# Altium schematic sheet BLUE_PILL.SchDoc
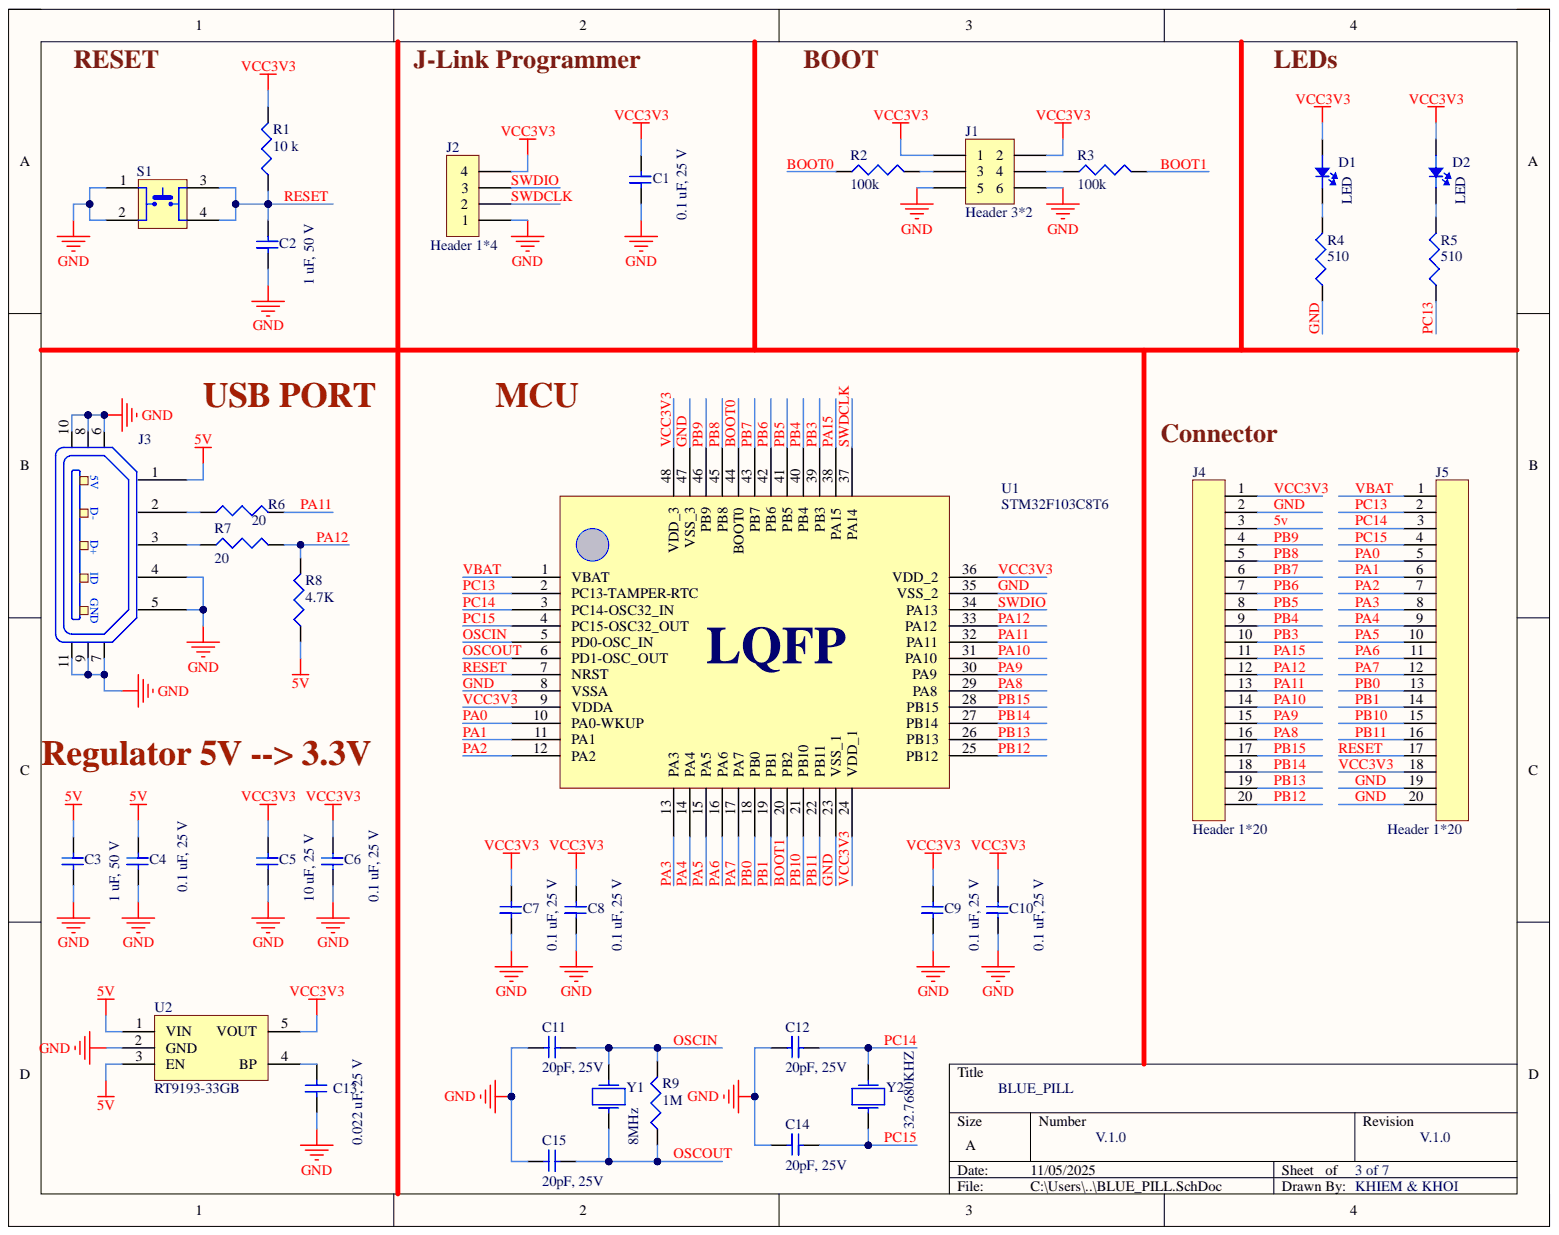
[diagram p0: BLUE_PILL.SchDoc]

## Parts
C1  0.1 uF, 25 V  [C0805]
C2  1 uF, 50 V  [C0805]
C3  1 uF, 50 V  [C0805]
C4  0.1 uF, 25 V  [C0805]
C5  10 uF, 25 V  [C0805]
C6  0.1 uF, 25 V  [C0805]
C7  0.1 uF, 25 V  [C0805]
C8  0.1 uF, 25 V  [C0805]
C9  0.1 uF, 25 V  [C0805]
C10  0.1 uF, 25 V  [C0805]
C11  20pF, 25V  [C0805]
C12  20pF, 25V  [C0805]
C13  0.022 uF, 25 V  [C0805]
C14  20pF, 25V  [C0805]
C15  20pF, 25V  [C0805]
D1  LED  [LED0603]
D2  LED  [LED0603]
J1  Header 3*2  [Header 3*2]
J2  Header 1*4  [Header 4*1]
J3  10118194-0001LF  [10118194-0001LF]  (value not drawn)
J4  Header 1*20  [header 20*1]
J5  Header 1*20  [header 20*1]
R1  10 k  [R0805]
R2  100k  [R0805]
R3  100k  [R0805]
R4  510  [R0805]
R5  510  [R0805]
R6  20  [R0805]
R7  20  [R0805]
R8  4.7K  [R0805]
R9  1M  [R0805]
S1  PTS526SM15SMTR2LFS  [PTS526SM15SMTR2LFS]  (value not drawn)
U1  STM32F103C8T6  Case/Package: LQFP  [LQFP48]
U2  RT9193-33GB  [SOT95P280X100-5N]
Y1  8MHz  [FC4SD]
Y2  32.7680KHZ  [CM8V-T1A]

## Nets
5v: C3.2 C4.2 J3.1 J4.3 R8.1 U2.1(VIN) U2.3(EN)
BOOT0: R2.1 U1.44(BOOT0)
BOOT1: R3.2 U1.20(PB2)
GND: C1.1 C2.1 C3.1 C4.1 C5.1 C6.1 C7.1 C8.1 C9.1 C10.1 C11.1 C12.1 C13.1 C14.1 C15.1 J1.5 J1.6 J2.1 J3.4 J3.5 J3.6 J3.7 J3.8 J3.9 J3.10 J3.11 J4.2 J5.19 J5.20 R4.1 S1.1 S1.2 U1.8(VSSA) U1.23(VSS_1) U1.35(VSS_2) U1.47(VSS_3) U2.2(GND)
OSCIN: C11.2 R9.2 U1.5(PD0-OSC_IN) Y1.2
OSCOUT: C15.2 R9.1 U1.6(PD1-OSC_OUT) Y1.1
PA0: J5.5 U1.10(PA0-WKUP)
PA1: J5.6 U1.11(PA1)
PA2: J5.7 U1.12(PA2)
PA3: J5.8 U1.13(PA3)
PA4: J5.9 U1.14(PA4)
PA5: J5.10 U1.15(PA5)
PA6: J5.11 U1.16(PA6)
PA7: J5.12 U1.17(PA7)
PA8: J4.16 U1.29(PA8)
PA9: J4.15 U1.30(PA9)
PA10: J4.14 U1.31(PA10)
PA11: J4.13 R6.2 U1.32(PA11)
PA12: J4.12 R7.2 R8.2 U1.33(PA12)
PA15: J4.11 U1.38(PA15)
PB0: J5.13 U1.18(PB0)
PB1: J5.14 U1.19(PB1)
PB3: J4.10 U1.39(PB3)
PB4: J4.9 U1.40(PB4)
PB5: J4.8 U1.41(PB5)
PB6: J4.7 U1.42(PB6)
PB7: J4.6 U1.43(PB7)
PB8: J4.5 U1.45(PB8)
PB9: J4.4 U1.46(PB9)
PB10: J5.15 U1.21(PB10)
PB11: J5.16 U1.22(PB11)
PB12: J4.20 U1.25(PB12)
PB13: J4.19 U1.26(PB13)
PB14: J4.18 U1.27(PB14)
PB15: J4.17 U1.28(PB15)
PC13: J5.2 R5.1 U1.2(PC13-TAMPER-RTC)
PC14: C12.2 J5.3 U1.3(PC14-OSC32_IN) Y2.2
PC15: C14.2 J5.4 U1.4(PC15-OSC32_OUT) Y2.1
RESET: C2.2 J5.17 R1.1 S1.3 S1.4 U1.7(NRST)
SWDCLK: J2.2 U1.37(PA14)
SWDIO: J2.3 U1.34(PA13)
VBAT: J5.1 U1.1(VBAT)
VCC3V3: C1.2 C5.2 C6.2 C7.2 C8.2 C9.2 C10.2 D1.1 D2.1 J1.1 J1.2 J2.4 J4.1 J5.18 R1.2 U1.9(VDDA) U1.24(VDD_1) U1.36(VDD_2) U1.48(VDD_3) U2.5(VOUT)
NetC13_2: C13.2 U2.4(BP)
NetD1_2: D1.2 R4.2
NetD2_2: D2.2 R5.2
NetJ1_3: J1.3 R2.2
NetJ1_4: J1.4 R3.1
NetJ3_2: J3.2 R6.1
NetJ3_3: J3.3 R7.1

## Library data of the parts
C1 C4 C6-C10 = C0805C104K3RACTU: Multilayer Ceramic Capacitor, 0.1 uF, 25 V, ± 10%, X7R, 0805 [2012 Metric]; Manufacturer: KEMET; Material: 4241ConductorMaterial; Temperature Coefficient: 30ppm/°C; Value: 100nF; Voltage Rating: 25V; Case Code (Imperial): 0805; Case Code (Metric): 2012; Case/Package: 0805; Category: Ceramic Capacitors; Component Kind: Standard; ComponentLink1Description: https://datasheet.ciiva.com/7842/kem-c1002-x7r-smd-7842538.pdf?src-supplier=Element14; ComponentLink1URL: https://datasheet.ciiva.com/7842/kem-c1002-x7r-smd-7842538.pdf?src-supplier=Element14; ComponentLink2Description: https://datasheet.ciiva.com/7842/kem-c1002-x7r-smd-7842536.pdf?src-supplier=Element14; ComponentLink2URL: https://datasheet.ciiva.com/7842/kem-c1002-x7r-smd-7842536.pdf?src-supplier=Element14; ComponentLink3Description: https://datasheet.ciiva.com/18193/getdatasheetpartid-385649-18193413.pdf?src-supplier=Verical; ComponentLink3URL: https://datasheet.ciiva.com/18193/getdatasheetpartid-385649-18193413.pdf?src-supplier=Verical; Construction: Flat; Depth: 1.25mm; Dielectric: X7R; Height: 0.9mm; Lead Free: 5697LeadFree; Lead Pitch: 0.75mm; Length: 2mm; Library Name: Blue_Pill_LIB.IntLib; Manufacturer Part Number: C0805C104K3RACTU; Max Operating Temperature: 125°C; Min Operating Temperature: -55°C; Mounting Technology: Surface Mount,PCB; Package Quantity: 4000; Packaging: Tape and Reel; Pins: 2NUMBER; Pricing: 1=0.11, 10=0.048, 50=0.048, 100=0.028, 1000=0.024, 10000=0.016 (USD); Radiation Hardening: No; Reach SVHC Compliant: 4186SVHC; RoHS: Yes; RoHS Compliant: Yes; Series: SMD Comm X7R; Stock: 364608; Supplier: Mouser; Supplier Part Number: 80-C0805C104K3R; Termination: SMD/SMT; Thickness: 889um; Tolerance: 10%; Voltage Rating (DC): 25V; Width: 1.2mm
C2 C3 = C0805C105K5RACTU: Multilayer Ceramic Capacitor, 1 uF, 50 V, ± 10%, X7R, 0805 [2012 Metric]; Manufacturer: KEMET; Material: 4241ConductorMaterial; Value: 1uF; Voltage: 50V; Case Code (Imperial): 0805; Case Code (Metric): 2012; Case/Package: 0805; Category: Ceramic Capacitors; Component Kind: Standard; ComponentLink1Description: https://datasheet.ciiva.com/7842/1732744-7842192.pdf?src-supplier=Element14; ComponentLink1URL: https://datasheet.ciiva.com/7842/1732744-7842192.pdf?src-supplier=Element14; ComponentLink2Description: https://datasheet.ciiva.com/22700/1851736-22700523.pdf?src-supplier=Element14; ComponentLink2URL: https://datasheet.ciiva.com/22700/1851736-22700523.pdf?src-supplier=Element14; Construction: Flat; Depth: 1.25mm; Dielectric: X7R; Dielectric Material: Ceramic; Height: 1.25mm; Length: 2mm; Library Name: Blue_Pill_LIB.IntLib; Manufacturer Part Number: C0805C105K5RACTU; Max Operating Temperature: 125°C; Min Operating Temperature: -55°C; Mounting Technology: Surface Mount,PCB; Package Quantity: 1; Packaging: Tape and Reel; Pricing: 1=0.34, 10=0.17, 50=0.17, 100=0.11, 1000=0.087, 10000=0.077 (USD); Reach SVHC Compliant: No; RoHS: Yes; RoHS Compliant: Yes; Series: C; Stock: 466053; Supplier: Mouser; Supplier Part Number: 80-C0805C105K5R; Termination: SMD/SMT; Thickness: 0.055inch; Tolerance: 10%; Voltage Rating: 50V; Voltage Rating (DC): 50V; Width: 0.049inch
C5 = CC0805KKX5R8BB106: Multilayer Ceramic Capacitor, 10 uF, 25 V, ± 10%, X5R, 0805 [2012 Metric]; Manufacturer: Yageo; Material: 4241ConductorMaterial; Value: 10uF; Voltage Rating: 25V; Case Code (Imperial): 0805; Case Code (Metric): 2012; Case/Package: 0805; Category: Ceramic Capacitors; Component Kind: Standard; ComponentLink1Description: http://www.mouser.com/ds/2/447/UPY-GPHC_X5R_4V-to-50V_25-1131599.pdf?src-supplier=Mouser; ComponentLink1URL: http://www.mouser.com/ds/2/447/UPY-GPHC_X5R_4V-to-50V_25-1131599.pdf?src-supplier=Mouser; ComponentLink2Description: https://datasheet.octopart.com/CC0805KKX5R8BB106-Yageo-datasheet-140260304.pdf?src-supplier=Newark; ComponentLink2URL: https://datasheet.octopart.com/CC0805KKX5R8BB106-Yageo-datasheet-140260304.pdf?src-supplier=Newark; ComponentLink3Description: https://datasheet.octopart.com/CC0805KKX5R8BB106-Yageo-datasheet-170350636.pdf?src-supplier=Future+Electronics; ComponentLink3URL: https://datasheet.octopart.com/CC0805KKX5R8BB106-Yageo-datasheet-170350636.pdf?src-supplier=Future+Electronics; ComponentLink4Description: http://www.yageo.com/documents/recent/UPY-GPHC_X5R_4V-to-50V_25.pdf?src-supplier=Digikey; ComponentLink4URL: http://www.yageo.com/documents/recent/UPY-GPHC_X5R_4V-to-50V_25.pdf?src-supplier=Digikey; Dielectric: X5R; Halogen Free: 5694HalogenFree; Height: 1.45mm; Lead Free: 5697LeadFree; Length: 0.079inch; Library Name: Blue_Pill_LIB.IntLib; Manufacturer Part Number: CC0805KKX5R8BB106; Max Operating Temperature: 85°C; Min Operating Temperature: -55°C; Mounting Technology: Surface Mount; Packaging: Tape and Reel; Pricing: 20=0.169, 100=0.135, 500=0.11, 800=0.154, 1000=0.093 (GBP); RoHS: Yes; RoHS Compliant: Yes; Series: CC; Stock: 60; Supplier: Rs; Supplier Part Number: 1988078; Termination: SMD/SMT; Thickness: 0.057inch; Tolerance: 10%; Voltage Rating (DC): 25V; Weight: 0.000194oz; Width: 0.049inch
C11 C12 C14 C15 = C0805C200J3HACAUTO: Cap Cer 0805 20PF 25V Ultra Stab; Manufacturer: KEMET; Category: Ceramic Capacitors; Component Kind: Standard; Library Name: Blue_Pill_LIB.IntLib; Manufacturer Part Number: C0805C200J3HACAUTO; Pricing: 1=0.15, 10=0.061, 50=0.061, 100=0.036, 1000=0.028, 10000=0.02 (USD); RoHS: Yes; RoHS Compliant: Yes; Stock: 11117; Supplier: Mouser; Supplier Part Number: 80-C0805C200J3HAUTO
C13 = C0805C223J3GACTU: Multilayer Ceramic Capacitor, 0.022 uF, 25 V, ± 5%, C0G (NP0), 0805 [2012 Metric]; Manufacturer: KEMET; Dielectric Material: 4241ConductorMaterial; Dissipation Factor: 0.1%; Temperature Coefficient: 30ppm/°C; Value: 22nF; Voltage: 25V; Case Code (Imperial): 0805; Case Code (Metric): 2012; Case/Package: 0805; Category: Ceramic Capacitors; Component Kind: Standard; ComponentLink1Description: http://components.arrow.com/part/dataview/46124259S8614547N7429?src-supplier=Arrow+Electronics; ComponentLink1URL: http://components.arrow.com/part/dataview/46124259S8614547N7429?src-supplier=Arrow+Electronics; ComponentLink2Description: http://www.farnell.com/datasheets/2741014.pdf?src-supplier=Farnell; ComponentLink2URL: http://www.farnell.com/datasheets/2741014.pdf?src-supplier=Farnell; ComponentLink3Description: http://www.mouser.com/ds/2/212/KEM_C1003_C0G_SMD-1101588.pdf?src-supplier=Mouser; ComponentLink3URL: http://www.mouser.com/ds/2/212/KEM_C1003_C0G_SMD-1101588.pdf?src-supplier=Mouser; ComponentLink4Description: https://datasheet.octopart.com/C0805C223J3GACTU-Kemet-datasheet-14356739.pdf?src-supplier=Newark; ComponentLink4URL: https://datasheet.octopart.com/C0805C223J3GACTU-Kemet-datasheet-14356739.pdf?src-supplier=Newark; ComponentLink5Description: https://api.kemet.com/component-edge/download/datasheet/C0805C223J3GACTU.pdf?src-supplier=Digi-Key; ComponentLink5URL: https://api.kemet.com/component-edge/download/datasheet/C0805C223J3GACTU.pdf?src-supplier=Digi-Key; ComponentLink6Description: http://www.kemet.com/docfinder?Partnumber=C0805C223J3GACTU&src-supplier=Digikey; ComponentLink6URL: http://www.kemet.com/docfinder?Partnumber=C0805C223J3GACTU&src-supplier=Digikey; ComponentLink7Description: https://www.verical.com/server-webapp/html/getDatasheet?partID=512137&src-supplier=Verical; ComponentLink7URL: https://www.verical.com/server-webapp/html/getDatasheet?partID=512137&src-supplier=Verical; ComponentLink8Description: http://www.farnell.com/datasheets/2741014.pdf?src-supplier=Newark; ComponentLink8URL: http://www.farnell.com/datasheets/2741014.pdf?src-supplier=Newark; ComponentLink9Description: https://datasheet.octopart.com/C0805C223J3GACTU-Kemet-datasheet-66532318.pdf?src-supplier=Element14; ComponentLink9URL: https://datasheet.octopart.com/C0805C223J3GACTU-Kemet-datasheet-66532318.pdf?src-supplier=Element14; ComponentLink10Description: https://datasheet.octopart.com/C0805C223J3GACTU-KEMET-datasheet-101576230.pdf?src-supplier=Farnell; ComponentLink10URL: https://datasheet.octopart.com/C0805C223J3GACTU-KEMET-datasheet-101576230.pdf?src-supplier=Farnell; ComponentLink11Description: http://www.farnell.com/datasheets/2741014.pdf?src-supplier=Element14; ComponentLink11URL: http://www.farnell.com/datasheets/2741014.pdf?src-supplier=Element14; ComponentLink12Description: http://capacitoredge.kemet.com/capedge2/DataSheet?pn=C0805C223J3GACTU&src-supplier=Avnet; ComponentLink12URL: http://capacitoredge.kemet.com/capedge2/DataSheet?pn=C0805C223J3GACTU&src-supplier=Avnet; ComponentLink13Description: https://datasheet.octopart.com/C0805C223J3GACTU-KEMET-datasheet-37178240.pdf?src-supplier=Electro+Sonic; ComponentLink13URL: https://datasheet.octopart.com/C0805C223J3GACTU-KEMET-datasheet-37178240.pdf?src-supplier=Electro+Sonic; Construction: Flat; Depth: 1.25mm; Dielectric: C0G; Height: 0.9mm; Lead Free: 5697LeadFree; Length: 2mm; Library Name: Blue_Pill_LIB.IntLib; Manufacturer Part Number: C0805C223J3GACTU; Material: 4241ConductorMaterial; Max Operating Temperature: 125°C; Min Operating Temperature: -55°C; Mounting Technology: Surface Mount; Package Quantity: 4000; Packaging: Tape and Reel; Pricing: 1=0.41, 10=0.27, 50=0.27, 100=0.163, 1000=0.109, 10000=0.088 (USD); Radiation Hardening: No; Reach SVHC Compliant: No; RoHS: Yes; RoHS Compliant: Yes; Series: SMD Comm C0G; Stock: 19291; Supplier: Mouser; Supplier Part Number: 80-C0805C223J3G; Termination: SMD/SMT; Thickness: 0.039inch; Tolerance: 5%; Voltage Rating: 25V; Voltage Rating (DC): 25V; Width: 1.2mm
D1 D2 = LTST-C191KFKT: Orange 0603 130° Water Clear 90 mcd 2 V SMT Rectangular Flat Top LED; Manufacturer: Vishay Lite-On; Dominant Wavelength: 605nm; Forward Current: 30mA; Forward Voltage: 2V; Lens Color: Colorless; Lens Size: 0.8mm; Lens Style: Flat,Diffused,Colorless,Rectangular; Luminous Intensity: 90mcd; Number of Elements: 1; Power Dissipation: 75mW; Reverse Voltage: 5V; Test Current: 20mA; Wavelength: 611nm; Case Code (Imperial): 0603; Case Code (Metric): 1608; Case/Package: 0603; Category: LEDs; Color: Orange; Component Kind: Standard; ComponentLink1Description: https://datasheet.ciiva.com/2109/ltst-c191kfkt-2109968.pdf?src-supplier=Digikey; ComponentLink1URL: https://datasheet.ciiva.com/2109/ltst-c191kfkt-2109968.pdf?src-supplier=Digikey; ComponentLink2Description: https://datasheet.ciiva.com/11775/0900766b80dc02b0-11775613.pdf?src-supplier=Rs; ComponentLink2URL: https://datasheet.ciiva.com/11775/0900766b80dc02b0-11775613.pdf?src-supplier=Rs; ComponentLink3Description: https://datasheet.ciiva.com/12400/100-ltst-c191kfkt-39904-12400811.pdf?src-supplier=Mouser; ComponentLink3URL: https://datasheet.ciiva.com/12400/100-ltst-c191kfkt-39904-12400811.pdf?src-supplier=Mouser; ComponentLink4Description: https://datasheet.ciiva.com/15201/getdatasheetpartid-631075-15201391.pdf?src-supplier=Verical; ComponentLink4URL: https://datasheet.ciiva.com/15201/getdatasheetpartid-631075-15201391.pdf?src-supplier=Verical; ComponentLink5Description: https://datasheet.ciiva.com/2109/s-110-ltst-c191kfkt-2109969.pdf?src-supplier=Digikey; ComponentLink5URL: https://datasheet.ciiva.com/2109/s-110-ltst-c191kfkt-2109969.pdf?src-supplier=Digikey; Depth: 0.8mm; Height: 0.65mm; Illumination Color: Orange; Lead Free: 5697LeadFree; Length: 1.6mm; Lens Transparency: Clear; Library Name: Blue_Pill_LIB.IntLib; Manufacturer Part Number: LTST-C191KFKT; Max Operating Temperature: 85°C; Min Operating Temperature: -55°C; Mounting Technology: Surface Mount; Number of LEDs: 1; Package Quantity: 5000; Packaging: Tape and Reel; Pins: 2; Power Rating: 75mW; Pricing: 40000=0.028, 125000=0.0261 (USD); Radiation Hardening: No; RoHS: Yes; RoHS Compliant: Yes; Series: -; Stock: 40000; Supplier: Arrow Electronics; Supplier Part Number: LTST-C191KFKT; Viewing Angle: 130°; Width: 0.8mm
J1 = M20-9980345: Conn Unshrouded Header HDR 6 POS 2.54mm Solder ST Top Entry Thru-Hole Bulk/Loose; Manufacturer: Harwin; Category: Headers and Wire Housings; Component Kind: Standard; ComponentLink1Description: https://datasheet.ciiva.com/6789/91635-6789923.pdf?src-supplier=Newark; ComponentLink1URL: https://datasheet.ciiva.com/6789/91635-6789923.pdf?src-supplier=Newark; ComponentLink2Description: https://datasheet.ciiva.com/11746/0900766b80da602b-11746662.pdf?src-supplier=Rs; ComponentLink2URL: https://datasheet.ciiva.com/11746/0900766b80da602b-11746662.pdf?src-supplier=Rs; ComponentLink3Description: https://datasheet.ciiva.com/26852/getdatasheetpartid-420465-26852189.pdf?src-supplier=Verical; ComponentLink3URL: https://datasheet.ciiva.com/26852/getdatasheetpartid-420465-26852189.pdf?src-supplier=Verical; ComponentLink4Description: https://datasheet.ciiva.com/8046/112195-8046904.pdf?src-supplier=Element14; ComponentLink4URL: https://datasheet.ciiva.com/8046/112195-8046904.pdf?src-supplier=Element14; ComponentLink5Description: https://datasheet.ciiva.com/6830/m20-998-6830775.pdf?src-supplier=Digikey; ComponentLink5URL: https://datasheet.ciiva.com/6830/m20-998-6830775.pdf?src-supplier=Digikey; Library Name: Blue_Pill_LIB.IntLib; Manufacturer Part Number: M20-9980345; Pricing: 250=0.146, 1000=0.124, 5000=0.1, 10000=0.092 (GBP); RoHS: Yes; Stock: 1710; Supplier: Rs; Supplier Part Number: 6812947P
J2 = M20-9960445: Conn Unshrouded Header HDR 4 POS 2.54mm Solder RA Thru-Hole Bag; Manufacturer: Harwin; Current Rating: 3A; Gender: Male; Number of Contacts: 4; Voltage Rating: 750V; Case/Package: SIL; Category: Headers and Wire Housings; Color: Black; Component Kind: Standard; ComponentLink1Description: https://datasheet.ciiva.com/9480/m20-996-9480181.pdf?src-supplier=Avnet; ComponentLink1URL: https://datasheet.ciiva.com/9480/m20-996-9480181.pdf?src-supplier=Avnet; ComponentLink2Description: https://datasheet.ciiva.com/12921/m20-996-12921995.pdf?src-supplier=Mouser; ComponentLink2URL: https://datasheet.ciiva.com/12921/m20-996-12921995.pdf?src-supplier=Mouser; Contact Finish - Mating: GOLD; Contact Material: Brass; Contact Mating Length: 0.24inch; Contact Plating: Gold; Contact Shape: Square; Contact Type: Male Pin; Fastening Type: Pull; Flammability Rating: UL94 V-0; Housing Material: Nylon; Insulation Color: Black; Insulation Material: Nylon; Library Name: Blue_Pill_LIB.IntLib; Manufacturer Part Number: M20-9960445; Max Operating Temperature: 105°C; Min Operating Temperature: -40°C; Mounting Technology: Through Hole; Number of Positions: 4; Number of Rows: 1; Package Quantity: 1000; Packaging: Bulk; Pitch: 2.54mm; Pricing: 1=0.31, 10=0.267, 100=0.2079, 1000=0.14847, 5000=0.12726, 10000=0.11878 (USD); RoHS: Yes; RoHS Compliant: Yes; Series: M20; Stock: 9573; Supplier: Digikey; Supplier Part Number: 952-3286-ND; Termination: Solder
J3 = 10118194-0001LF: CONN USB MICRO B RECPT SMT R/A; Manufacturer: Amphenol ICC / FCI; Connector Type: USB,Receptacle; Current Rating: 1.8A; Gender: Receptacle; Insulation Resistance: 1GR; Max Voltage Rating (AC): 100V; Number of Contacts: 5; Number of Ports: 1; Category: USB Connectors; Color: Black; Component Kind: Standard; Contact Material: Copper Alloy; Contact Plating: Gold; Depth: 5mm; Features: Shielded; Flammability Rating: UL94 V-0; Housing Material: Polymer; Length: 8.05mm; Library Name: Blue_Pill_LIB.IntLib; Manufacturer Part Number: 10118194-0001LF; Max Operating Temperature: 85°C; Min Operating Temperature: -55°C; Mounting Technology: Surface Mount,Horizontal; Orientation: Right Angle; Package Quantity: 9600; Packaging: Tape and Reel; Pins: 5; Pricing: 9600=0.1965 (USD); RoHS: No; Series: -; Stock: 19200; Supplier: Arrow Electronics; Supplier Part Number: 10118194-0001LF; Termination: Solder
J4 J5 = M20-9992045: 2.54mm (0.1") Pitch SIL Vertical PC Tail Pin Header, 6.1mm mating pin height, gold (hi-temp mould), 20 contacts; Manufacturer: Harwin; Gender: Male; Number of Contacts: 20; Case/Package: SIL; Category: Headers and Wire Housings; Color: Black; Component Kind: Standard; ComponentLink1Description: https://datasheet.ciiva.com/5159/m20-999-351179-5159781.pdf?src-supplier=Mouser; ComponentLink1URL: https://datasheet.ciiva.com/5159/m20-999-351179-5159781.pdf?src-supplier=Mouser; Contact Mating Length: 0.24inch; Contact Plating: Gold; Contact Type: Male Pin; Library Name: Blue_Pill_LIB.IntLib; Manufacturer Part Number: M20-9992045; Mounting Technology: Through Hole; Number of Positions: 20; Number of Rows: 1; Package Quantity: 200; Packaging: Bulk; Pitch: 2.54mm; Pricing: 10=0.766, 50=0.699, 100=0.632, 250=0.575, 500=0.517, 1500=0.46 (EUR); Radiation Hardening: No; RoHS: Yes; RoHS Compliant: Yes; Series: M20; Stock: 1665; Supplier: Farnell; Supplier Part Number: 3226019; Termination: Solder
R1 = RC0805JR-0710KL: SMD Chip Resistor, 10 kOhm, ± 5%, 125 mW, 0805 [2012 Metric], Thick Film, General Purpose; Manufacturer: Yageo; Max Power Dissipation: 125mW; Temperature Coefficient: 100ppm/°C; Value: 10kR; Voltage Rating: 150V; Case Code (Imperial): 0805; Case Code (Metric): 2012; Case/Package: 0805; Category: Chip SMD Resistors; Component Kind: Standard; ComponentLink1Description: https://datasheet.ciiva.com/7983/444164-7983765.pdf?src-supplier=Element14; ComponentLink1URL: https://datasheet.ciiva.com/7983/444164-7983765.pdf?src-supplier=Element14; ComponentLink2Description: https://datasheet.ciiva.com/7941/444164-7941870.pdf?src-supplier=Element14; ComponentLink2URL: https://datasheet.ciiva.com/7941/444164-7941870.pdf?src-supplier=Element14; ComponentLink3Description: https://datasheet.ciiva.com/7941/444164-7941871.pdf?src-supplier=Element14; ComponentLink3URL: https://datasheet.ciiva.com/7941/444164-7941871.pdf?src-supplier=Element14; Construction: Rectangular; Contact Plating: Tin,Matte; Depth: 1.25mm; Features: Moisture Resistant; Height: 0.5mm; Lead Free: 5697LeadFree; Length: 2mm; Library Name: Blue_Pill_LIB.IntLib; Manufacturer Part Number: RC0805JR-0710KL; Max Operating Temperature: 155°C; Military Standard: Not; Min Operating Temperature: -55°C; Number of Terminations: 2; Packaging: Tape and Reel; Power Rating: 125mW; Pricing: 5000=0.0023, 25000=0.0021, 100000=0.002, 250000=0.002, 625000=0.0018 (USD); Radiation Hardening: No; Reach SVHC Compliant: Unknown; Resistance Tolerance: 5%; Resistor Type: Thick Film; RoHS: Yes; RoHS Compliant: Yes; Series: RC; Stock: 9465000; Supplier: Future Electronics; Supplier Part Number: 9330259; Termination: SMD/SMT; Tolerance: 5%; Width: 1.25mm
R2 R3 = RC0805FR-07100KL: SMD Chip Resistor, 100 kOhm, ± 1%, 125 mW, 0805 [2012 Metric], Thick Film, General Purpose; Manufacturer: Yageo; Max Power Dissipation: 125mW; Temperature Coefficient: 100ppm/°C; Value: 100kR; Voltage Rating: 150V; Case Code (Imperial): 0805; Case Code (Metric): 2012; Case/Package: 0805; Category: Chip SMD Resistors; Component Kind: Standard; ComponentLink1Description: https://datasheet.ciiva.com/11808/0900766b8106beab-11808858.pdf?src-supplier=Rs; ComponentLink1URL: https://datasheet.ciiva.com/11808/0900766b8106beab-11808858.pdf?src-supplier=Rs; ComponentLink2Description: https://datasheet.ciiva.com/7941/1686128-7941677.pdf?src-supplier=Element14; ComponentLink2URL: https://datasheet.ciiva.com/7941/1686128-7941677.pdf?src-supplier=Element14; ComponentLink3Description: https://datasheet.ciiva.com/7660/38627-7660796.pdf?src-supplier=Element14; ComponentLink3URL: https://datasheet.ciiva.com/7660/38627-7660796.pdf?src-supplier=Element14; ComponentLink4Description: https://datasheet.ciiva.com/22747/444164-22747534.pdf?src-supplier=Element14; ComponentLink4URL: https://datasheet.ciiva.com/22747/444164-22747534.pdf?src-supplier=Element14; ComponentLink5Description: https://datasheet.ciiva.com/2457/pyu-r-int-thick-7-2457018.pdf?src-supplier=Digikey; ComponentLink5URL: https://datasheet.ciiva.com/2457/pyu-r-int-thick-7-2457018.pdf?src-supplier=Digikey; ComponentLink6Description: https://datasheet.ciiva.com/2457/pyu-r-int-thick-7-2457019.pdf?src-supplier=Digikey; ComponentLink6URL: https://datasheet.ciiva.com/2457/pyu-r-int-thick-7-2457019.pdf?src-supplier=Digikey; ComponentLink7Description: https://datasheet.ciiva.com/2457/pyu-r-int-thick-7-2457017.pdf?src-supplier=Digikey; ComponentLink7URL: https://datasheet.ciiva.com/2457/pyu-r-int-thick-7-2457017.pdf?src-supplier=Digikey; ComponentLink8Description: https://datasheet.ciiva.com/12667/rc0805-12667001.pdf?src-supplier=Mouser; ComponentLink8URL: https://datasheet.ciiva.com/12667/rc0805-12667001.pdf?src-supplier=Mouser; ComponentLink9Description: https://datasheet.ciiva.com/7941/1697719-7941676.pdf?src-supplier=Element14; ComponentLink9URL: https://datasheet.ciiva.com/7941/1697719-7941676.pdf?src-supplier=Element14; ComponentLink10Description: https://datasheet.ciiva.com/7941/1697719-7941678.pdf?src-supplier=Element14; ComponentLink10URL: https://datasheet.ciiva.com/7941/1697719-7941678.pdf?src-supplier=Element14; ComponentLink11Description: https://datasheet.ciiva.com/16747/rc0805-16747226.pdf?src-supplier=Future+Electronics; ComponentLink11URL: https://datasheet.ciiva.com/16747/rc0805-16747226.pdf?src-supplier=Future+Electronics; Construction: Rectangular; Depth: 1.25mm; Features: Moisture Resistant; Height: 0.024inch; Lead Free: 5697LeadFree; Lead Pitch: 0.4mm; Length: 0.079inch; Library Name: Blue_Pill_LIB.IntLib; Manufacturer Part Number: RC0805FR-07100KL; Max Operating Temperature: 155°C; Military Standard: Not; Min Operating Temperature: -55°C; Number of Terminations: 2; Package Quantity: 1; Packaging: Tape and Reel; Pins: 2; Power Rating: 125mW; Pricing: 5000=0.0027, 25000=0.0025, 100000=0.0024, 250000=0.0023, 625000=0.0021 (USD); Reach SVHC Compliant: Unknown; Resistance Tolerance: 1%; Resistor Type: Thick Film; RoHS: Yes; RoHS Compliant: Yes; Series: RC; Stock: 2010000; Supplier: Future Electronics; Supplier Part Number: 2952521; Technology: THICK FILM; Termination: SMD/SMT; Tolerance: 1%; Voltage Rating (AC): 150V; Voltage Rating (DC): 150V; Width: 0.049inch
R4 R5 = RC0805JR-07510RL: Res Thick Film 0805 510 Ohm 5% 1/8W ±100ppm/°C SMD Paper T/R; Manufacturer: Yageo; Max Power Dissipation: 125mW; Value: 510R; Voltage Rating: 150V; Voltage Rating (DC): 300V; Case Code (Imperial): 0805; Case Code (Metric): 2012; Case/Package: 0805; Category: Chip SMD Resistors; Component Kind: Standard; ComponentLink1Description: https://datasheet.ciiva.com/2519/pyu-r-int-thick-7-2519247.pdf?src-supplier=Digikey; ComponentLink1URL: https://datasheet.ciiva.com/2519/pyu-r-int-thick-7-2519247.pdf?src-supplier=Digikey; ComponentLink2Description: https://datasheet.ciiva.com/2519/pyu-r-int-thick-7-2519248.pdf?src-supplier=Digikey; ComponentLink2URL: https://datasheet.ciiva.com/2519/pyu-r-int-thick-7-2519248.pdf?src-supplier=Digikey; ComponentLink3Description: https://datasheet.ciiva.com/2519/pyu-r-int-thick-7-2519246.pdf?src-supplier=Digikey; ComponentLink3URL: https://datasheet.ciiva.com/2519/pyu-r-int-thick-7-2519246.pdf?src-supplier=Digikey; ComponentLink4Description: https://datasheet.ciiva.com/12656/rc0805-12656876.pdf?src-supplier=Mouser; ComponentLink4URL: https://datasheet.ciiva.com/12656/rc0805-12656876.pdf?src-supplier=Mouser; ComponentLink5Description: https://datasheet.ciiva.com/16741/rc0805-16741256.pdf?src-supplier=Future+Electronics; ComponentLink5URL: https://datasheet.ciiva.com/16741/rc0805-16741256.pdf?src-supplier=Future+Electronics; Construction: Rectangular; Contact Plating: Tin,Lead; Depth: 1.25mm; Features: Moisture Resistant; Lead Free: Lead Free; Length: 2mm; Library Name: Blue_Pill_LIB.IntLib; Manufacturer Part Number: RC0805JR-07510RL; Max Operating Temperature: 155°C; Military Standard: Not; Min Operating Temperature: -55°C; Number of Terminations: 2; Packaging: Tape and Reel; Power Rating: 125mW; Pricing: 5000=0.0023, 25000=0.0021, 50000=0.0022, 100000=0.002, 200000=0.0021, 250000=0.002, 625000=0.002, 2000000=0.0018 (USD); RoHS: Yes; RoHS Compliant: Yes; Series: RC; Stock: 200000; Supplier: Future Electronics; Supplier Part Number: 3650397; Technology: THICK FILM; Temperature Coefficient: 100ppm/°C; Termination: SMD/SMT; Tolerance: 5%; Working Voltage: 150V
R6 R7 = RC0805JR-0720RL: SMD Chip Resistor, 20 Ohm, ± 5%, 125 mW, 0805 [2012 Metric], Thick Film, General Purpose; Manufacturer: Yageo; Category: Chip SMD Resistors; Component Kind: Standard; ComponentLink1Description: https://datasheet.ciiva.com/17053/rc0805-17053597.pdf?src-supplier=Future+Electronics; ComponentLink1URL: https://datasheet.ciiva.com/17053/rc0805-17053597.pdf?src-supplier=Future+Electronics; ComponentLink2Description: https://datasheet.ciiva.com/2456/pyu-r-int-thick-7-2456300.pdf?src-supplier=Digikey; ComponentLink2URL: https://datasheet.ciiva.com/2456/pyu-r-int-thick-7-2456300.pdf?src-supplier=Digikey; ComponentLink3Description: https://datasheet.ciiva.com/2456/pyu-r-int-thick-7-2456301.pdf?src-supplier=Digikey; ComponentLink3URL: https://datasheet.ciiva.com/2456/pyu-r-int-thick-7-2456301.pdf?src-supplier=Digikey; ComponentLink4Description: https://datasheet.ciiva.com/2456/pyu-r-int-thick-7-2456302.pdf?src-supplier=Digikey; ComponentLink4URL: https://datasheet.ciiva.com/2456/pyu-r-int-thick-7-2456302.pdf?src-supplier=Digikey; Library Name: Blue_Pill_LIB.IntLib; Manufacturer Part Number: RC0805JR-0720RL; Pricing: 5000=0.0035, 25000=0.0033, 50000=0.0033, 100000=0.0031, 150000=0.0032, 250000=0.003, 625000=0.003, 1500000=0.0028 (USD); RoHS: Yes; Stock: 370000; Supplier: Future Electronics; Supplier Part Number: 9756750
R8 = RC0805FR-134K7L: Res Thick Film 0805 4.7K Ohm 1% 1/8W ±100ppm/°C SMD Paper T/R; Manufacturer: Yageo; Category: Chip SMD Resistors; Component Kind: Standard; ComponentLink1Description: http://www.mouser.com/ds/2/447/Yageo_08112016_RC0805-968729.pdf?src-supplier=Mouser; ComponentLink1URL: http://www.mouser.com/ds/2/447/Yageo_08112016_RC0805-968729.pdf?src-supplier=Mouser; ComponentLink2Description: http://www.yageo.com/pdf/PYu-RC0805_51_RoHS_L_4.pdf?src-supplier=Avnet; ComponentLink2URL: http://www.yageo.com/pdf/PYu-RC0805_51_RoHS_L_4.pdf?src-supplier=Avnet; ComponentLink3Description: https://datasheet.octopart.com/RC0805FR-134K7L-Yageo-datasheet-164759695.pdf?src-supplier=Future+Electronics; ComponentLink3URL: https://datasheet.octopart.com/RC0805FR-134K7L-Yageo-datasheet-164759695.pdf?src-supplier=Future+Electronics; ComponentLink4Description: https://datasheet.octopart.com/RC0805FR-134K7L-Yageo-datasheet-148775077.pdf?src-supplier=TME; ComponentLink4URL: https://datasheet.octopart.com/RC0805FR-134K7L-Yageo-datasheet-148775077.pdf?src-supplier=TME; Library Name: Blue_Pill_LIB.IntLib; Manufacturer Part Number: RC0805FR-134K7L; Pricing: 20000=0.0027, 60000=0.0026, 200000=0.0025, 400000=0.0024, 800000=0.0023 (USD); RoHS: Yes; Stock: 800000; Supplier: Future Electronics; Supplier Part Number: 7067790
R9 = RC0805JR-131ML: SMD Chip Resistor, 1 MOhm, ± 5%, 125 mW, 0805 [2012 Metric], Thick Film, General Purpose; Manufacturer: Yageo; Power Rating: 125mW; Temperature Coefficient: 100ppm/°C; Value: 1MR; Voltage Rating: 150V; Case Code (Imperial): 0805; Case Code (Metric): 2012; Case/Package: 0805; Category: Chip SMD Resistors; Component Kind: Standard; ComponentLink1Description: http://www.mouser.com/ds/2/447/Yageo_08112016_RC0805-968729.pdf?src-supplier=Mouser; ComponentLink1URL: http://www.mouser.com/ds/2/447/Yageo_08112016_RC0805-968729.pdf?src-supplier=Mouser; ComponentLink2Description: http://www.yageo.com/documents/recent/PYu-RC_Group_51_RoHS_L_2.pdf?src-supplier=Avnet; ComponentLink2URL: http://www.yageo.com/documents/recent/PYu-RC_Group_51_RoHS_L_2.pdf?src-supplier=Avnet; Contact Plating: Tin,Matte; Height: 0.5mm; Length: 2mm; Library Name: Blue_Pill_LIB.IntLib; Manufacturer Part Number: RC0805JR-131ML; Max Operating Temperature: 155°C; Min Operating Temperature: -55°C; Packaging: Tape and Reel; Pricing: 1=0.1, 10=0.023, 50=0.0144, 100=0.012, 500=0.00818, 1000=0.00706, 5000=0.00513, 10000=0.00454 (USD); RoHS: Yes; RoHS Compliant: Yes; Series: RC; Stock: 25426; Supplier: Digikey; Supplier Part Number: 13-RC0805JR-131MLCT-ND; Termination: SMD/SMT; Tolerance: 5%; Width: 1.25mm
S1 = PTS526SM15SMTR2LFS: Switch Tactile N.O. SPST Round Button Gull Wing 0.05A 12VDC 500000Cycles 1.57N SMD T/R; Manufacturer: ITT C&K; Contact Resistance: 100mR; Number of Positions: 2NUMBER; Category: Tactile Switches; Component Kind: Standard; ComponentLink1Description: https://dznh3ojzb2azq.cloudfront.net/products/Tactile/PTS526/documents/datasheet.pdf?src-supplier=Digikey; ComponentLink1URL: https://dznh3ojzb2azq.cloudfront.net/products/Tactile/PTS526/documents/datasheet.pdf?src-supplier=Digikey; ComponentLink2Description: https://datasheet.octopart.com/PTS526SM15SMTR2LFS-C%26K-Components-datasheet-138817219.pdf?src-supplier=Future+Electronics; ComponentLink2URL: https://datasheet.octopart.com/PTS526SM15SMTR2LFS-C%26K-Components-datasheet-138817219.pdf?src-supplier=Future+Electronics; ComponentLink3Description: https://datasheet.octopart.com/PTS526SM15SMTR2LFS-C%26K-Components-datasheet-166147767.pdf?src-supplier=TME; ComponentLink3URL: https://datasheet.octopart.com/PTS526SM15SMTR2LFS-C%26K-Components-datasheet-166147767.pdf?src-supplier=TME; Library Name: Blue_Pill_LIB.IntLib; Manufacturer Part Number: PTS526SM15SMTR2LFS; Pricing: 1=0.14, 10=0.135, 25=0.1276, 100=0.1162, 250=0.1092, 500=0.09988, 1000=0.08363 (USD); RoHS: No; Stock: 7522; Supplier: Digikey; Supplier Part Number: CKN12220-1-ND
U1 = STM32F103C8T6: ARM Cortex-M3 STM32 F1 Microcontroller 32-Bit 72MHz 64kB FLASH LQFP48 STM32F103C8T6; Manufacturer: STMicroelectronics; Data Bus Width: 32b; Interface: I2C,SPI,USB,CAN,USART; Max Supply Voltage: 3.6V; Min Supply Voltage: 2V; Nominal Supply Current: 1.7uA; Number of A/D Converters: 2; Number of ADC Channels: 10; Number of I/Os: 37; Number of Timers/Counters: 3; RAM Size: 20KB; Category: Microcontrollers; Clock Frequency: 72MHz; Component Kind: Standard; ComponentLink1Description: https://datasheet.ciiva.com/15122/getdatasheetpartid-69880-15122076.pdf?src-supplier=Verical; ComponentLink1URL: https://datasheet.ciiva.com/15122/getdatasheetpartid-69880-15122076.pdf?src-supplier=Verical; ComponentLink2Description: https://datasheet.ciiva.com/8054/1730126-8054942.pdf?src-supplier=Element14; ComponentLink2URL: https://datasheet.ciiva.com/8054/1730126-8054942.pdf?src-supplier=Element14; ComponentLink3Description: https://datasheet.ciiva.com/14100/pustm-arm-microcont-stm32f103-en-14100508.pdf?src-supplier=Distrelec; ComponentLink3URL: https://datasheet.ciiva.com/14100/pustm-arm-microcont-stm32f103-en-14100508.pdf?src-supplier=Distrelec; ComponentLink4Description: https://datasheet.ciiva.com/16210/cd00161566-16210248.pdf?src-supplier=Future+Electronics; ComponentLink4URL: https://datasheet.ciiva.com/16210/cd00161566-16210248.pdf?src-supplier=Future+Electronics; ComponentLink5Description: https://datasheet.ciiva.com/48687/001075658-da-01-en-mcu-64kb-mem-stm32f103c8t6-lqfp-48-stm-48687589.pdf?src-supplier=Conrad; ComponentLink5URL: https://datasheet.ciiva.com/48687/001075658-da-01-en-mcu-64kb-mem-stm32f103c8t6-lqfp-48-stm-48687589.pdf?src-supplier=Conrad; Core Architecture: ARM; Height: 1.4mm; Lead Free: Lead Free; Length: 7mm; Library Name: Blue_Pill_LIB.IntLib; Manufacturer Part Number: STM32F103C8T6; Max Operating Temperature: 85°C; Memory Format: FLASH; Memory Size: 64KB; Min Operating Temperature: -40°C; Number of Channels: 10; Package Quantity: 1500; Packaging: Tray; Pins: 48; Pricing: 1=6.11, 10=5.53, 50=5.27, 100=4.58, 1000=3.47, 10000=3.33 (USD); RoHS: Yes; RoHS Compliant: Yes; Series: STM32F103C8; Stock: 24929; Supplier: Mouser; Supplier Part Number: 511-STM32F103C8T6; Weight: 0.006349oz; Width: 7mm
U2 = RT9193-33GB: LDO Regulator Pos 3.3V 0.3A 5-Pin SOT-23; Manufacturer: Richtek; Category: Voltage Regulators - Linear; Component Kind: Standard; ComponentLink1Description: https://datasheet.ciiva.com/2046/3d3futf-83fb3frfm5mtkzlte2lnbkzg3d3d3f3d-2046896.pdf?src-supplier=Digikey; ComponentLink1URL: https://datasheet.ciiva.com/2046/3d3futf-83fb3frfm5mtkzlte2lnbkzg3d3d3f3d-2046896.pdf?src-supplier=Digikey; ComponentLink2Description: https://datasheet.ciiva.com/28652/1782157-28652989.pdf?src-supplier=Element14; ComponentLink2URL: https://datasheet.ciiva.com/28652/1782157-28652989.pdf?src-supplier=Element14; Library Name: Blue_Pill_LIB.IntLib; Manufacturer Part Number: RT9193-33GB; Pricing: 1=0.53, 10=0.454, 25=0.424, 100=0.3394, 250=0.31512, 500=0.26664, 1000=0.20604 (USD); RoHS: Yes; Stock: 26926; Supplier: Digikey; Supplier Part Number: 1028-1014-1-ND
Y1 = FC4SDCBMF8.0-T1: Crystal 8MHz ±30ppm (Tol) ±50ppm (Stability) 20pF FUND 80Ohm 2-Pin HC-49/SDLF SMD T/R; Manufacturer: Fox Electronics; ESR (Equivalent Series Resistance): 80R; Component Kind: Standard; ComponentLink1Description: http://www.foxonline.com/pdfs/C4SD.pdf?src-supplier=Digikey; ComponentLink1URL: http://www.foxonline.com/pdfs/C4SD.pdf?src-supplier=Digikey; ComponentLink2Description: http://datasheet.octopart.com/FC4SDCBMF8.0-T1-Fox-Electronics-datasheet-136840669.pdf?src-supplier=Rs; ComponentLink2URL: http://datasheet.octopart.com/FC4SDCBMF8.0-T1-Fox-Electronics-datasheet-136840669.pdf?src-supplier=Rs; Frequency: 8MHz; Frequency Stability: 50ppm; Frequency Tolerance: 30ppm; Library Name: Blue_Pill_LIB.IntLib; Load Capacitance: 20pF; Manufacturer Part Number: FC4SDCBMF8.0-T1; Max Operating Temperature: 70°C; Min Operating Temperature: -20°C; Mounting Technology: Surface Mount; Packaging: Cut Tape; Pricing: 1000=0.1586, 3000=0.157, 5000=0.1562 (USD); RoHS: Yes; Series: HC49SDLF; Stock: 12000; Supplier: Arrow Electronics; Supplier Part Number: FC4SDCBMF8.0-T1
Y2 = CM8V-T1A-32.768KHZ-7PF-20PPM-TA-QC: Crystal 32.7680KHZ 7PF SMD; Manufacturer: Micro Crystal; Component Kind: Standard; Library Name: Blue_Pill_LIB.IntLib; Manufacturer Part Number: CM8V-T1A-32.768KHZ-7PF-20PPM-TA-QC; Pricing: 1=0.5881, 10=0.4944, 50=0.4378, 100=0.3862, 500=0.3784, 1000=0.2933, 3000=0.2822, 5000=0.27, 10000=0.2581 (USD); RoHS: No; Stock: 87569; Supplier: Arrow Electronics; Supplier Part Number: CM8V-T1A-32.768KHZ-7PF-20PPM-TA-QC
